# Altium schematic sheet mcu_power_supply.SchDoc
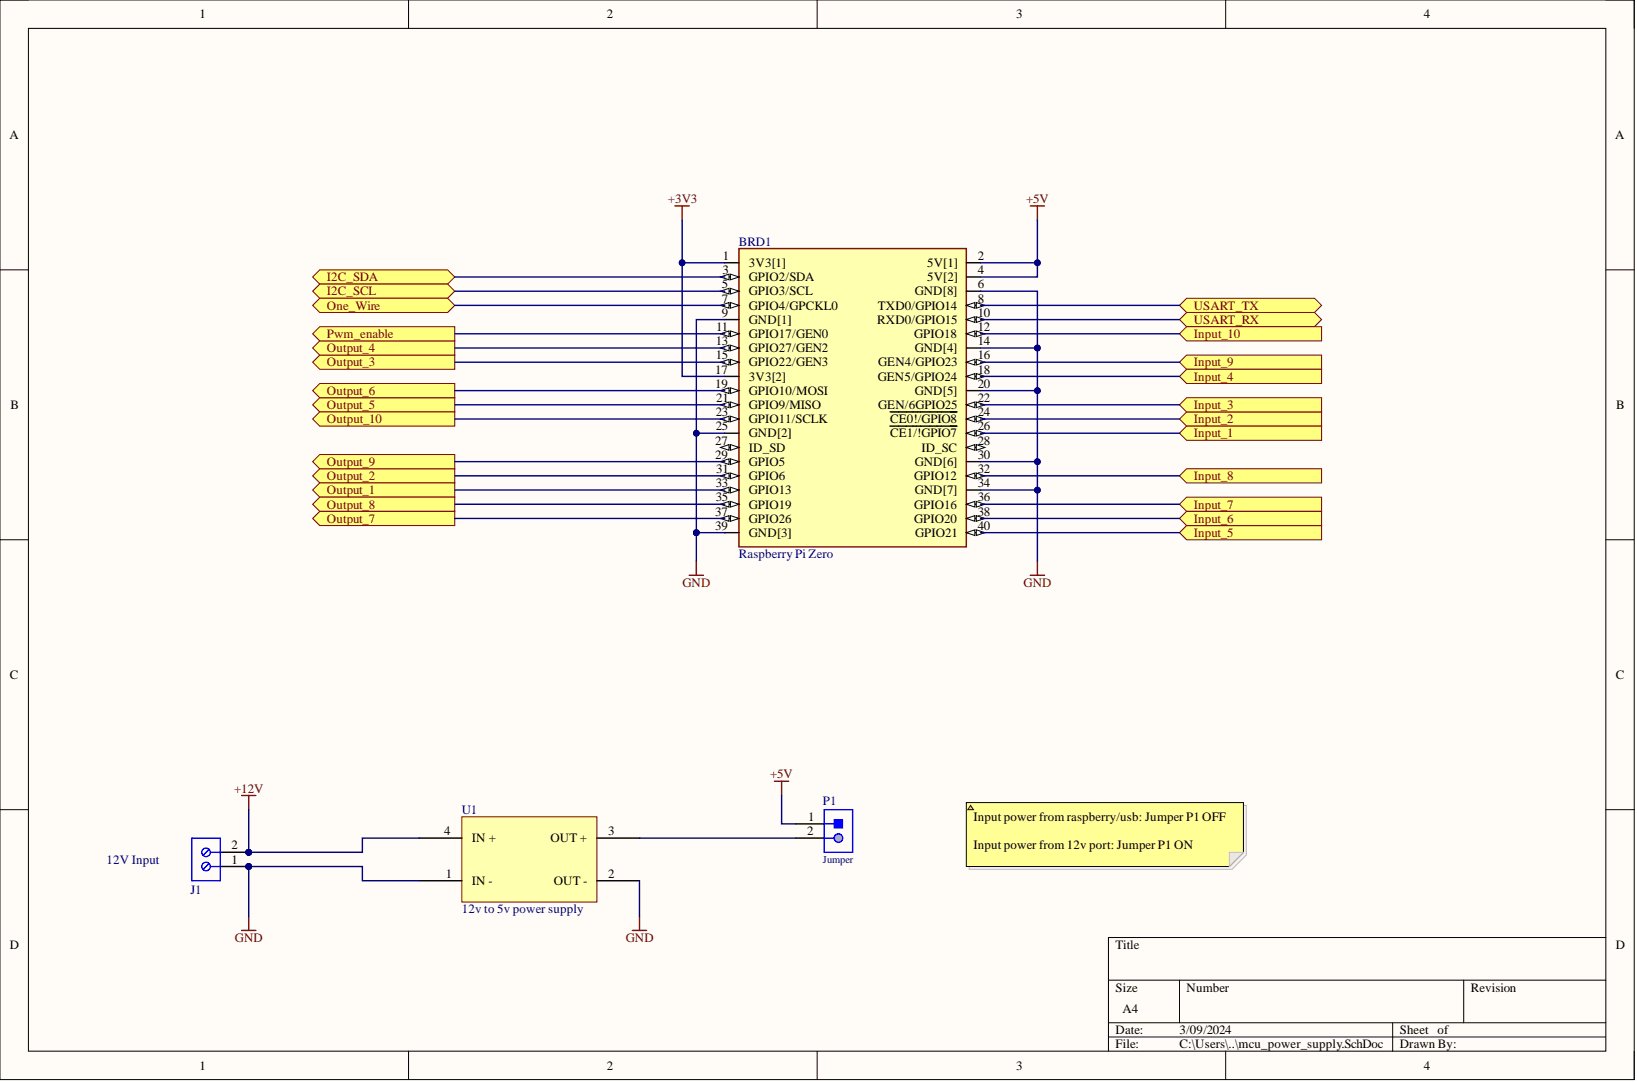
[diagram p0: mcu_power_supply.SchDoc]

## Parts
BRD1  Raspberry Pi Zero  MF: Raspberry Pi  [RASPBERRY_PI_ZERO]
J1  282836-2  [TECO-282836-2_V]  (value not drawn)
P1  Jumper  [61300211121]
U1  12v to 5v power supply  [5v_power_supply]

## Ports
I2C_SCL, I2C_SDA, Input_1, Input_2, Input_3, Input_4, Input_5, Input_6, Input_7, Input_8, Input_9, Input_10, One_Wire, Output_1, Output_2, Output_3, Output_4, Output_5, Output_6, Output_7, Output_8, Output_9, Output_10, Pwm_enable, USART_RX, USART_TX

## Nets
+3V3: BRD1.1(3V3[1]) BRD1.17(3V3[2])
+5V: BRD1.2(5V[1]) BRD1.4(5V[2]) P1.1
+12V: J1.2 U1.4(IN_+)
GND: BRD1.6(GND[8]) BRD1.9(GND[1]) BRD1.14(GND[4]) BRD1.20(GND[5]) BRD1.25(GND[2]) BRD1.30(GND[6]) BRD1.34(GND[7]) BRD1.39(GND[3]) J1.1 U1.1(IN_-) U1.2(OUT_-)
I2C_SCL: BRD1.5(GPIO3/SCL) ; port I2C_SCL
I2C_SDA: BRD1.3(GPIO2/SDA) ; port I2C_SDA
Input_1: BRD1.26(CE1/!GPIO7) ; port Input_1
Input_2: BRD1.24(CE0!/GPIO8) ; port Input_2
Input_3: BRD1.22(GEN/6GPIO25) ; port Input_3
Input_4: BRD1.18(GEN5/GPIO24) ; port Input_4
Input_5: BRD1.40(GPIO21) ; port Input_5
Input_6: BRD1.38(GPIO20) ; port Input_6
Input_7: BRD1.36(GPIO16) ; port Input_7
Input_8: BRD1.32(GPIO12) ; port Input_8
Input_9: BRD1.16(GEN4/GPIO23) ; port Input_9
Input_10: BRD1.12(GPIO18) ; port Input_10
One_Wire: BRD1.7(GPIO4/GPCKL0) ; port One_Wire
Output_1: BRD1.33(GPIO13) ; port Output_1
Output_2: BRD1.31(GPIO6) ; port Output_2
Output_3: BRD1.15(GPIO22/GEN3) ; port Output_3
Output_4: BRD1.13(GPIO27/GEN2) ; port Output_4
Output_5: BRD1.21(GPIO9/MISO) ; port Output_5
Output_6: BRD1.19(GPIO10/MOSI) ; port Output_6
Output_7: BRD1.37(GPIO26) ; port Output_7
Output_8: BRD1.35(GPIO19) ; port Output_8
Output_9: BRD1.29(GPIO5) ; port Output_9
Output_10: BRD1.23(GPIO11/SCLK) ; port Output_10
Pwm_enable: BRD1.11(GPIO17/GEN0) ; port Pwm_enable
USART_RX: BRD1.10(RXD0/GPIO15) ; port USART_RX
USART_TX: BRD1.8(TXD0/GPIO14) ; port USART_TX
NetP1_2: P1.2 U1.3(OUT_+)

Not connected: BRD1 27(ID_SD) 28(ID_SC)

## Library data of the parts
BRD1 = MCU_raspberryPi_zero: Raspberry Pi Zero with Header; MP: ADA3708; Availability: Unavailable; Component Kind: Standard; Library Name: integrated_library.IntLib; Package: None; Price: None; SnapEDA_Link: https://www.snapeda.com/parts/ADA3708/Raspberry%20Pi/view-part/2770118/?ref=snap
J1 = CMP-1684-00017-1: Female Header, Pitch 5 mm, 1 x 2 Position, Height 10 mm, Tail Length 3.5 mm, Rohs, Bulk; Manufacturer: TE Connectivity; 3D Model: 282836-2.pdf; Clamp Material - Plating: Brass-NickelPlated; Current: 13.5A; Dynamic Catalog: BuchananSeries; Featured Product: EurostyleTerminalBlockConnectors; Mating Orientation: HorizontalwithBoard; Number of Levels: 1; Other Names: 5ULV-02B  A98076; Pitch: 0.197"(5.00mm); Positions Per Level: 2; Product Training Modules: Wire-to-BoardEurostyleTerminalBlocks; Screw Thread: M3; Torque - Screw: 0.68Nm(6Lb-In); Voltage: 300V; Wire Gauge: 16-30AWG; Wire Termination: Screw-RisingCageClamp; Category: Connectors,Interconnects; Color: Green; Component Kind: Standard; Contact Material - Plating: Brass-TinPlated; Datasheet URL: http://www.te.com.cn/commerce/DocumentDelivery/DDEController?Action=showdoc&DocId=Catalog+Section%7F1308389_EUROSTYLE_TERMINAL_BLOCKS%7F0607%7Fpdf%7FEnglish%7FENG_CS_1308389_EUROSTYLE_TERMINAL_BLOCKS_0607.pdf%7F282836-2; Family: TerminalBlocks-WiretoBoard; Features: Interlocking(Side); Housing Material: Polyamide(PA66),Nylon6/6; Library Name: Altium Content Vault; Manufacturer URL: http://www.te.com; Mounting Type: ThroughHole; Package Description: Female Header, Pitch 5 mm, 1 x 2 Position, Height 10 mm, Tail Length 3.5 mm; Package Reference: THD; Packaging: Bulk; RoHS Information: 282836-2StatementofCompliance; Screw Material - Plating: Brass-NickelPlated; Series: Buchanan; Standard Package: 300
P1 = CMP-1712-00002-4: THT Vertical Pin Header WR-PHD, Pitch 2.54 mm, Single Row, 2 pins; Manufacturer: Wurth Elektronik; Contact Resistance: 20mOhm; Gender: Male; Pin Number: 2; Pitch: 2.54mm; Rated Current: 3A; Withstanding Voltage: 500VAC; Working Voltage: 250VAC; Component Kind: Standard; Datasheet URL: https://katalog.we-online.de/em/datasheet/6130xx11121.pdf; Library Name: Altium Content Vault; Manufacturer URL: http://www.we-online.de; Mounting Technology: THT; Operating Temperature: -40to105degC; Orientation: Vertical; Package Reference: 61300211121; Packaging: Bag; Part Number: 61300211121; Row Number: Single; Publisher: WurthElektronik
U1 = power_supply_5v: 12V TO 5V buck converter; Component Kind: Standard; Library Name: Schlib.SchLib
